# Altium schematic sheet sdd_microcontroller.SchDoc(sdd_microcontroller)
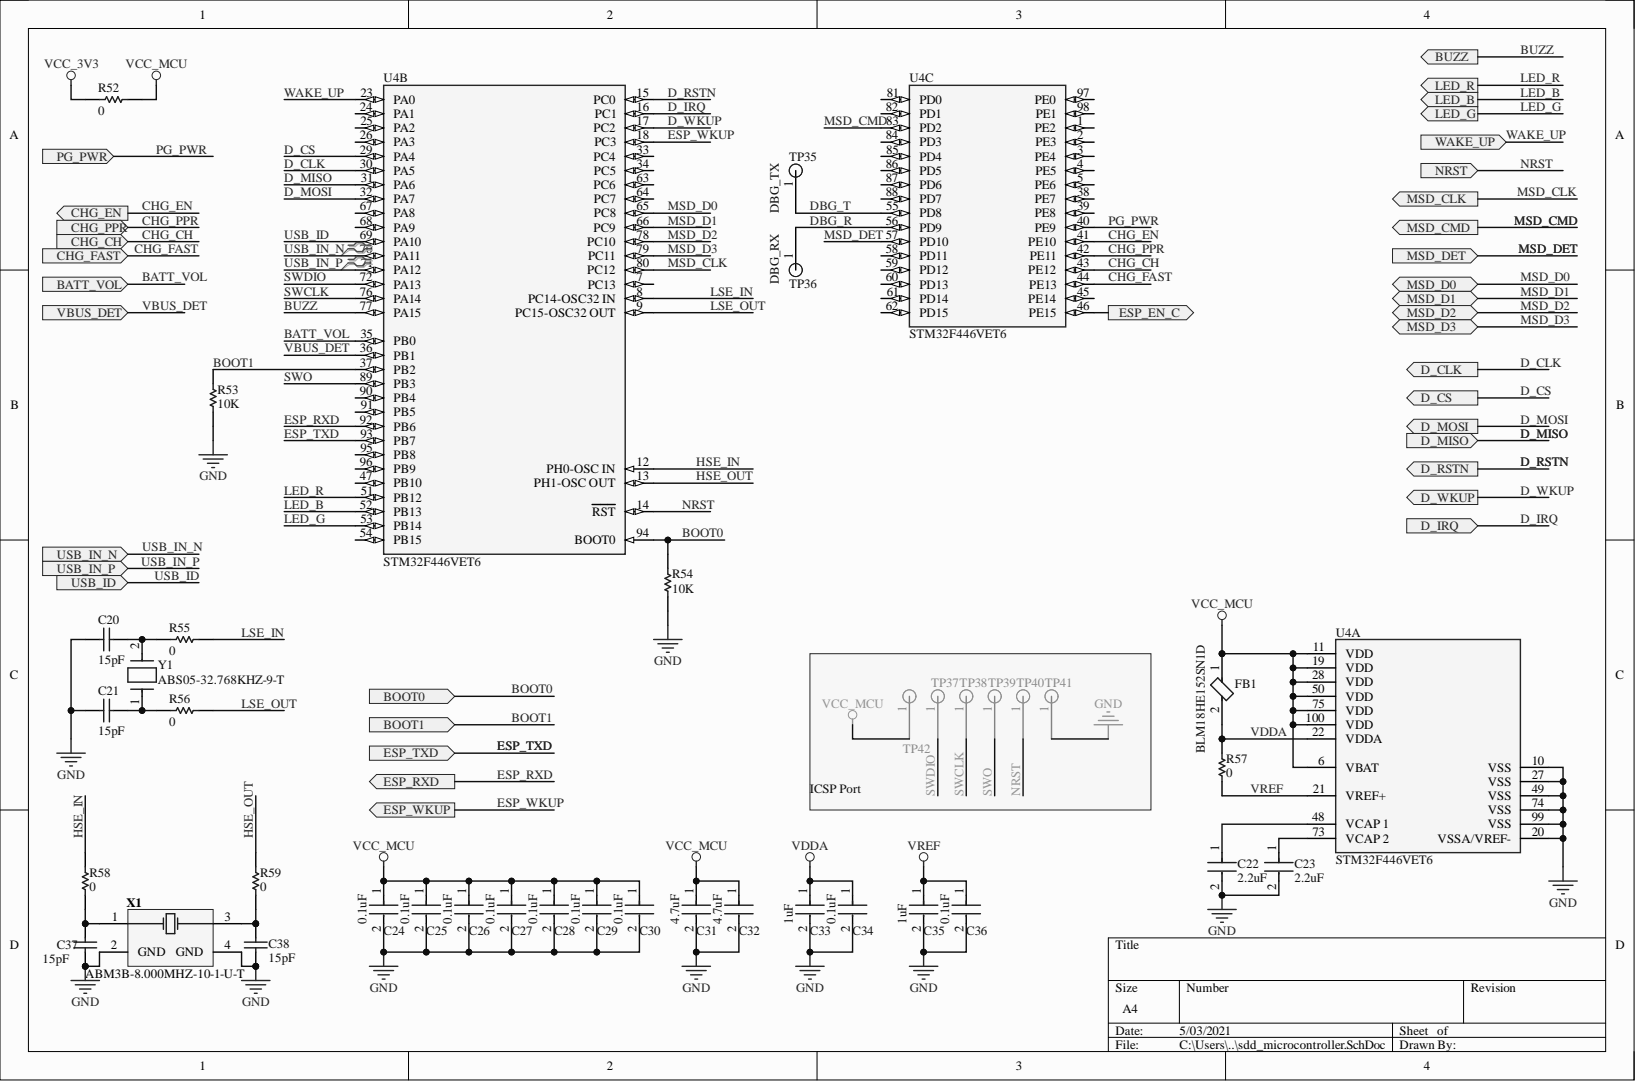
[diagram p0: sdd_microcontroller.SchDoc]

## Parts
C20  15pF  [CAPC1005X56X25LL10T15]
C21  15pF  [CAPC1005X56X25LL10T15]
C22  2.2uF  [FP-CL10-IPC_C]
C23  2.2uF  [FP-CL10-IPC_C]
C24  0.1uF  [FP-GRM022-0_02-IPC_A]
C25  0.1uF  [FP-GRM022-0_02-IPC_A]
C26  0.1uF  [FP-GRM022-0_02-IPC_A]
C27  0.1uF  [FP-GRM022-0_02-IPC_A]
C28  0.1uF  [FP-GRM022-0_02-IPC_A]
C29  0.1uF  [FP-GRM022-0_02-IPC_A]
C30  0.1uF  [FP-GRM022-0_02-IPC_A]
C31  4.7uF  [FP-GRM155-0_1-IPC_B]
C32  4.7uF  [FP-GRM155-0_1-IPC_B]
C33  1uF  [FP-CC0402-MFG]
C34  0.1uF  [FP-GRM022-0_02-IPC_A]
C35  1uF  [FP-CC0402-MFG]
C36  0.1uF  [FP-GRM022-0_02-IPC_A]
C37  15pF  [CAPC1005X56X25LL10T15]
C38  15pF  [CAPC1005X56X25LL10T15]
FB1  BLM18HE152SN1D  [FP-BLM18-0_15-t0_8-IPC_A]
R52  0  [RESC1005X40X25NL05T10]
R53  10K  [RESC1608X55X30ML20T20]
R54  10K  [RESC1608X55X30ML20T20]
R55  0  [RESC1005X40X25NL05T10]
R56  0  [RESC1005X40X25NL05T10]
R57  0  [RESC1005X40X25NL05T10]
R58  0  [RESC1005X40X25NL05T10]
R59  0  [RESC1005X40X25NL05T10]
TP35  DBG_TX  [Testpoint2]  (no BOM)
TP36  DBG_RX  [Testpoint2]  (no BOM)
TP37  Testpoint2  [Testpoint2]  (value not drawn)  (no BOM)
TP38  Testpoint2  [Testpoint2]  (value not drawn)  (no BOM)
TP39  Testpoint2  [Testpoint2]  (value not drawn)  (no BOM)
TP40  Testpoint2  [Testpoint2]  (value not drawn)  (no BOM)
TP41  Testpoint2  [Testpoint2]  (value not drawn)  (no BOM)
TP42  TP_1  [Testpoint1]  (value not drawn)  (no BOM)
U4  STM32F446VET6  [FP-LQFP100-1L-MFG]  (parts A,B,C)
X1  ABM3B-8.000MHZ-10-1-U-T  [ABRA-ABM3B_V]
Y1  ABS05-32.768KHZ-9-T  [FP-ABS05-MFG]

## Ports
BATT_VOL, BOOT0, BOOT1, BUZZ, CHG_CH, CHG_EN, CHG_FAST, CHG_PPR, D_CLK, D_CS, D_IRQ, D_MISO, D_MOSI, D_RSTN, D_WKUP, ESP_EN_C, ESP_RXD, ESP_TXD, ESP_WKUP, LED_B, LED_G, LED_R, MSD_CLK, MSD_CMD, MSD_D0, MSD_D1, MSD_D2, MSD_D3, MSD_DET, NRST, PG_PWR, USB_ID, USB_IN_N, USB_IN_P, VBUS_DET, WAKE_UP

## Nets
GND: C20.2 C21.2 C22.2 C23.2 C24.2 C25.2 C26.2 C27.2 C28.2 C29.2 C30.2 C31.2 C32.2 C33.2 C34.2 C35.2 C36.2 C37.1 C38.2 R53.2 R54.1 TP41.1 U4.10(VSS) U4.20(VSSA/VREF-) U4.27(VSS) U4.49(VSS) U4.74(VSS) U4.99(VSS) X1.2(GND) X1.4(GND)
VCC_3V3: R52.2
VCC_MCU: C24.1 C25.1 C26.1 C27.1 C28.1 C29.1 C30.1 C31.1 C32.1 FB1.1 R52.1 TP42.1 U4.6(VBAT) U4.11(VDD) U4.19(VDD) U4.28(VDD) U4.50(VDD) U4.75(VDD) U4.100(VDD)
BATT_VOL: U4.35(PB0) ; port BATT_VOL
BOOT0: R54.2 U4.94(BOOT0) ; port BOOT0
BOOT1: R53.1 U4.37(PB2) ; port BOOT1
BUZZ: U4.77(PA15) ; port BUZZ
CHG_CH: U4.43(PE12) ; port CHG_CH
CHG_EN: U4.41(PE10) ; port CHG_EN
CHG_FAST: U4.44(PE13) ; port CHG_FAST
CHG_PPR: U4.42(PE11) ; port CHG_PPR
D_CLK: U4.30(PA5) ; port D_CLK
D_CS: U4.29(PA4) ; port D_CS
D_IRQ: U4.16(PC1) ; port D_IRQ
D_MISO: U4.31(PA6) ; port D_MISO
D_MOSI: U4.32(PA7) ; port D_MOSI
D_RSTN: U4.15(PC0) ; port D_RSTN
D_WKUP: U4.17(PC2) ; port D_WKUP
DBG_R: TP36.1 U4.56(PD9)
DBG_T: TP35.1 U4.55(PD8)
ESP_EN_C: U4.46(PE15) ; port ESP_EN_C
ESP_RXD: U4.92(PB6) ; port ESP_RXD
ESP_TXD: U4.93(PB7) ; port ESP_TXD
ESP_WKUP: U4.18(PC3) ; port ESP_WKUP
HSE_IN: R58.2 U4.12(PH0-OSC_IN)
HSE_OUT: R59.2 U4.13(PH1-OSC_OUT)
LED_B: U4.52(PB13) ; port LED_B
LED_G: U4.53(PB14) ; port LED_G
LED_R: U4.51(PB12) ; port LED_R
LSE_IN: R55.2 U4.8(PC14-OSC32_IN)
LSE_OUT: R56.2 U4.9(PC15-OSC32_OUT)
MSD_CLK: U4.80(PC12) ; port MSD_CLK
MSD_CMD: U4.83(PD2) ; port MSD_CMD
MSD_D0: U4.65(PC8) ; port MSD_D0
MSD_D1: U4.66(PC9) ; port MSD_D1
MSD_D2: U4.78(PC10) ; port MSD_D2
MSD_D3: U4.79(PC11) ; port MSD_D3
MSD_DET: U4.57(PD10) ; port MSD_DET
NRST: TP40.1 U4.14(RST) ; port NRST
PG_PWR: U4.40(PE9) ; port PG_PWR
SWCLK: TP38.1 U4.76(PA14)
SWDIO: TP37.1 U4.72(PA13)
SWO: TP39.1 U4.89(PB3)
USB_ID: U4.69(PA10) ; port USB_ID
USB_IN_N: U4.70(PA11) ; port USB_IN_N
USB_IN_P: U4.71(PA12) ; port USB_IN_P
VBUS_DET: U4.36(PB1) ; port VBUS_DET
VDDA: C33.1 C34.1 FB1.2 R57.2 U4.22(VDDA)
VREF: C35.1 C36.1 R57.1 U4.21(VREF+)
WAKE_UP: U4.23(PA0) ; port WAKE_UP
NetC20_1: C20.1 R55.1 Y1.2
NetC21_1: C21.1 R56.1 Y1.1
NetC22_1: C22.1 U4.48(VCAP_1)
NetC23_1: C23.1 U4.73(VCAP_2)
NetC37_2: C37.2 R58.1 X1.1
NetC38_1: C38.1 R59.1 X1.3

Not connected: U4 1(PE2) 2(PE3) 3(PE4) 4(PE5) 5(PE6) 7(PC13) 24(PA1) 25(PA2) 26(PA3) 33(PC4) 34(PC5) 38(PE7) 39(PE8) 45(PE14) 47(PB10) 54(PB15) 58(PD11) 59(PD12) 60(PD13) 61(PD14) 62(PD15) 63(PC6) 64(PC7) 67(PA8) 68(PA9) 81(PD0) 82(PD1) 84(PD3) 85(PD4) 86(PD5) 87(PD6) 88(PD7) 90(PB4) 91(PB5) 95(PB8) 96(PB9) 97(PE0) 98(PE1)

## Library data of the parts
C20 C21 C37 C38 = CMP-1034-00812-2: Manufacturer: AVX Interconnect / Elco; Part Number: 04025A150FAT2A; Component Kind: Standard; Library Name: Altium Content Vault
C22 C23 = CMP-13271-002410-1: Cap Ceramic 2.2uF 6.3V X5R ±10% Pad SMD 0603 +85°C T/R; Capacitance: 2.2uF; Case Code (Imperial): 0603; Case Code (Metric): 1608; Case/Package: 0603; Component Kind: Standard; Contact Plating: Tin; Depth: 0.8mm; Dielectric: X5R; Height: 0.8mm; Lead Free: Lead Free; Length: 1.6mm; Library Name: Altium Content Vault; Max Operating Temperature: 85°C; Min Operating Temperature: -55°C; Mount: Surface Mount; Package Quantity: 1; Packaging: Tape and Reel; Radiation Hardening: No; Thickness: 0.035inch; Tolerance: 10%; Voltage: 6.3V; Voltage Rating: 6.3V; Voltage Rating (DC): 6.3V; Width: 0.031inch
C24-C30 C34 C36 = CMP-06035-048848-1: Chip Multilayer Ceramic Capacitors for General Purpose, 01005, 0.10uF, X5R, 15%, 20%, 6.3V; Capacitance: 100nF; Case Code (Imperial): 1005; Case Code (Metric): 1005; Case/Package: 0402; Component Kind: Standard; Dielectric: X5R; Height: 0.2mm; Lead Free: Lead Free; Lead Pitch: 0.232inch; Length: 0.016inch; Library Name: Altium Content Vault; Max Operating Temperature: 85°C; Min Operating Temperature: -55°C; Mount: Surface Mount; Package Quantity: 40000; Packaging: Tape and Reel; Termination: SMD/SMT; Thickness: 0.009inch; Tolerance: 20%; Voltage Rating: 6.3V; Voltage Rating (DC): 6.3V; Weight: 0.000212oz; Width: 0.2mm
C31 C32 = CMP-2008-04549-2: Chip Multilayer Ceramic Capacitors for General Purpose, 0402, 4.7uF, X5R, 15%, 20%, 6.3V; Capacitance: 4.7uF; Case Code (Imperial): 0402; Case Code (Metric): 1005; Case/Package: 0402; Component Kind: Standard; Contact Plating: Tin; Depth: 0.5mm; Dielectric: X5R; Height: 0.5mm; Lead Free: Lead Free; Length: 1mm; Library Name: Altium Content Vault; Max Operating Temperature: 85°C; Min Operating Temperature: -55°C; Mount: Surface Mount; Package Quantity: 10000; Packaging: Tape and Reel; REACH SVHC: No SVHC; Termination: SMD/SMT; Thickness: 0.022inch; Tolerance: 20%; Voltage: 6.3V; Voltage Rating: 6.3V; Voltage Rating (DC): 6.3V; Weight: 0.000212oz; Width: 0.02inch
C33 C35 = CMP-03422-000766-1: CAP CER 1UF 6.3V X5R 0402; Manufacturer: YAGEO; Manufacturer Part Number: CC0402MRX5R5BB105; Automotive Grade: NA; Material: Ceramic; Temperature Coefficient: 30ppm/°C; Tolerance: 0.2; Automotive: No; Capacitance: 1 uF; Category: Cap; Component Kind: Standard; ComponentLink2Description: Datasheet; ComponentLink2URL: http://www.yageo.com/documents/recent/UPY-GP_NP0_16V-to-50V_16.pdf; Device Class L1: Passive Components; Device Class L2: Capacitors; Device Class L3: Ceramic Capacitors; Digikey Description: CAP CER 1UF 6.3V X5R 0402; Footprint Url: http://www.yageo.com/exep/pages/download/literatures/UPY-C_GEN_24.pdf; Height: 0.55mm; Ipc Land Pattern Name: CAPC10050X50; Lead Free: Yes; Library Name: Altium Content Vault; Mouser Description: 0402 1 uF 6.3 V ±20 % Tolerance X5R SMT Multilayer Ceramic Capacitor; Octopart: https://octopart.com/cc0402mrx5r5bb105-yageo-9292746; Package: CC0402; RoHS: TRUE; Temperature: -55°C to +125°C; Temperature Characteristic: X5R; Temperature Range High: +125°C; Temperature Range Low: -55°C; Value: 1 uF; Voltage Rating: 6.3 V
FB1 = CMP-0686-00368-3: Chip Ferrite Bead, 0603, 1500Ω @ 100MHz, 0.5Ω, 25%, 500mA; DC Resistance (DCR): 500mR; Impedance: 1.5kR; Number of Circuits: 1; Case Code (Imperial): 0603; Case Code (Metric): 1608; Case/Package: 0603; Component Kind: Standard; Core Material: Ferrite; Current Rating: 500mA; DC Current: 500mA; Depth: 0.8mm; Height: 0.8mm; Lead Free: Lead Free; Length: 1.6mm; Library Name: Altium Content Vault; Material: Ferrite; Max Current Rating: 500mA; Max DC Current: 500mA; Max Operating Temperature: 125°C; Military Standard: Not; Min Operating Temperature: -55°C; Number of Lines: 1; Number of Pins: 2; Package Quantity: 1; Packaging: Tape and Reel; Radiation Hardening: No; REACH SVHC: No SVHC; Termination: SMD/SMT; Test Frequency: 100MHz; Tolerance: 25%; Width: 0.8mm
R52 R55-R59 = CMP-1011-00001-2: Manufacturer: Yageo / Phycomp; Part Number: RC0402JR-070RL; Component Kind: Standard; Library Name: Altium Content Vault
R53 R54 = CMP-2000-00874-1: Manufacturer: Panasonic; Part Number: ERA-3AEB103V; Component Kind: Standard; Library Name: Altium Content Vault
TP35 TP36 = Testpoint2: Library Name: TestPoint_Round.IntLib
TP42 = Testpoint1: Library Name: TestPoint.IntLib
U4 = CMP-12105-000075-1: IC MCU 32BIT 512KB FLASH 100LQFP; Manufacturer: STMicroelectronics; Core Architecture: ARM Cortex-M4; Data Bus Width: 32bits; Frequency: 180MHz; Interface: I2C,SPI,UART,USART,Parallel,CAN,USB,LIN,IrDA,I2S,Other; Max Junction Temp: +125°C; Max Supply Voltage: 3.6V; Memory Size: 512KBytes; Min Supply Voltage: 1.65V; Nominal Supply Current: 1.4-86mA; Number Of A/D Converters: 3; Number Of Adc Channels: 16; Number Of Cores: 1; Number Of I2C Channels: 4; Number Of I/Os: 81; Number Of Timers/Counters: 14; Number Of Uart Channels: 6; Number Of Usb Channels: 2; Ram Size: 128KBytes; Standoff Height: 0.05mm; Ambient Temperature Range High: +85°C; Ambient Temperature Range Low: -40°C; Automotive: No; Category: IC; Component Kind: Standard; ComponentLink2Description: Datasheet; ComponentLink2URL: https://www.st.com/resource/en/datasheet/stm32f446ve.pdf; Device Class L1: Integrated Circuits (ICs); Device Class L2: Embedded Processors and Controllers; Device Class L3: Microcontrollers; Digikey Description: IC MCU 32BIT 512KB FLASH 100LQFP; Height: 1.6mm; Ipc Land Pattern Name: QFP50P1600X1600X160-100; Lead Free: Yes; Library Name: Altium Content Vault; Manufacturer Part Number: STM32F446VET6; Memory Type: FLASH; Mouser Description: ARM Microcontrollers - MCU High-performance and DSP with FPU, ARM Cortex-M4 MCU with 512 Kbytes Flash, 180 MHz CPU, Art Accelerator, SDRAM; Number Of Spi Channels: 4; Octopart: https://octopart.com/stm32f446vet6-stmicroelectronics-52642922; Package: LQFP100-1L; RoHS: TRUE; Temperature: -40°C to +85°C; Temperature Range High: +85°C; Temperature Range Low: -40°C
X1 = CMP-2000-05730-1: Ceramic Crystal, 8 MHz, +/- 10 ppm, -10 to 60 degC, 4-Pin SMD, RoHS, Tape and Reel; Manufacturer: Abracon-LLC; ESR (Equivalent Series Resistance): 200Ohm; Frequency: 8MHz; Frequency Stability: ±10ppm; Load Capacitance: 10pF; Operating Mode: Fundamental; Category: CrystalsandOscillators; Component Kind: Standard; Datasheet URL: http://www.abracon.com/Resonators/abm3b.pdf; Datasheet Version: 08/2015; Family: Crystals; Frequency Tolerance: ±10ppm; Height: 0.043"(1.10mm); Library Name: Altium Content Vault; Manufacturer URL: http://www.abracon.com/; Mounting Technology: SurfaceMount; Mounting Type: SurfaceMount; Operating Temperature: -10°C~60°C; Package / Case: 4-SMD,NoLead(DFN,LCC); Package Description: 4-Pin Surface Mount Device, Body 5 x 3.2 mm; Package Reference: ABM3B; Packaging: Tape&amp;Reel(TR); Ratings: -; Series: ABM3B; Size / Dimension: 0.197"Lx0.126"W(5.00mmx3.20mm); Type: MHzCrystal
Y1 = CMP-27762-000002-1: CRYSTAL 32.7680KHZ 9PF SMD; Manufacturer: Abracon; Esr: 90kΩ; Tolerance: 20ppm; Automotive: No; Category: Crys; Component Kind: Standard; ComponentLink2Description: Datasheet; ComponentLink2URL: https://abracon.com/Resonators/ABS05.pdf; Device Class L1: Passive Components; Device Class L2: Crystals / Oscillators; Device Class L3: Crystals; Digikey Description: CRYSTAL 32.7680KHZ 9PF SMD; Frequency: 32.768kHz; Height: 0.5mm; Lead Free: Yes; Library Name: Altium Content Vault; Load Capacitance: 9pF; Manufacturer Part Number: ABS05-32.768KHZ-9-T; Mouser Description: ABS05 Series 32.768 kHz ±20 ppm 9 pF -40 to +85 °C SMT Low Profile Crystal; Octopart: https://octopart.com/abs05-32.768khz-9-t-abracon-24147054; Package: ABS05; RoHS: TRUE; Series: ABS05; Temperature: -40°C to +85°C; Temperature Range High: +85°C; Temperature Range Low: -40°C
TP37-TP41 = Testpoint2: Library Name: TestPoint_Round.IntLib
